# Altium schematic sheet STM32F103RET6.SchDoc
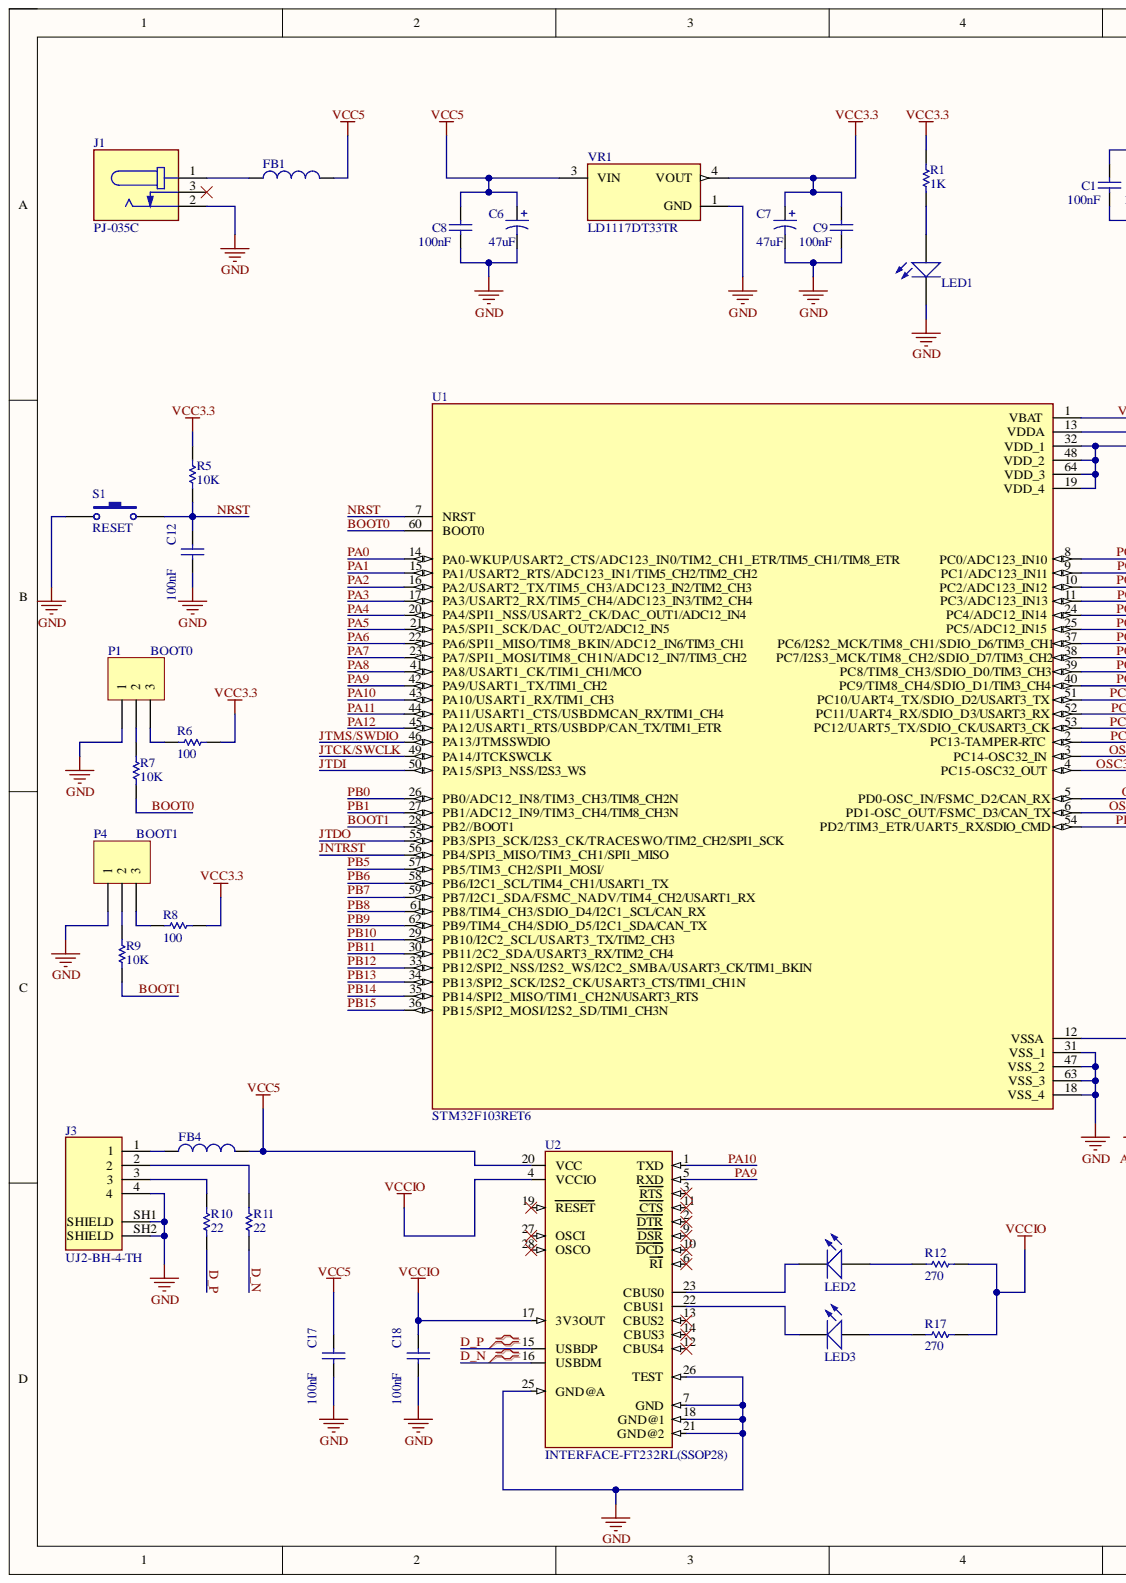
[diagram p0: STM32F103RET6.SchDoc, left]
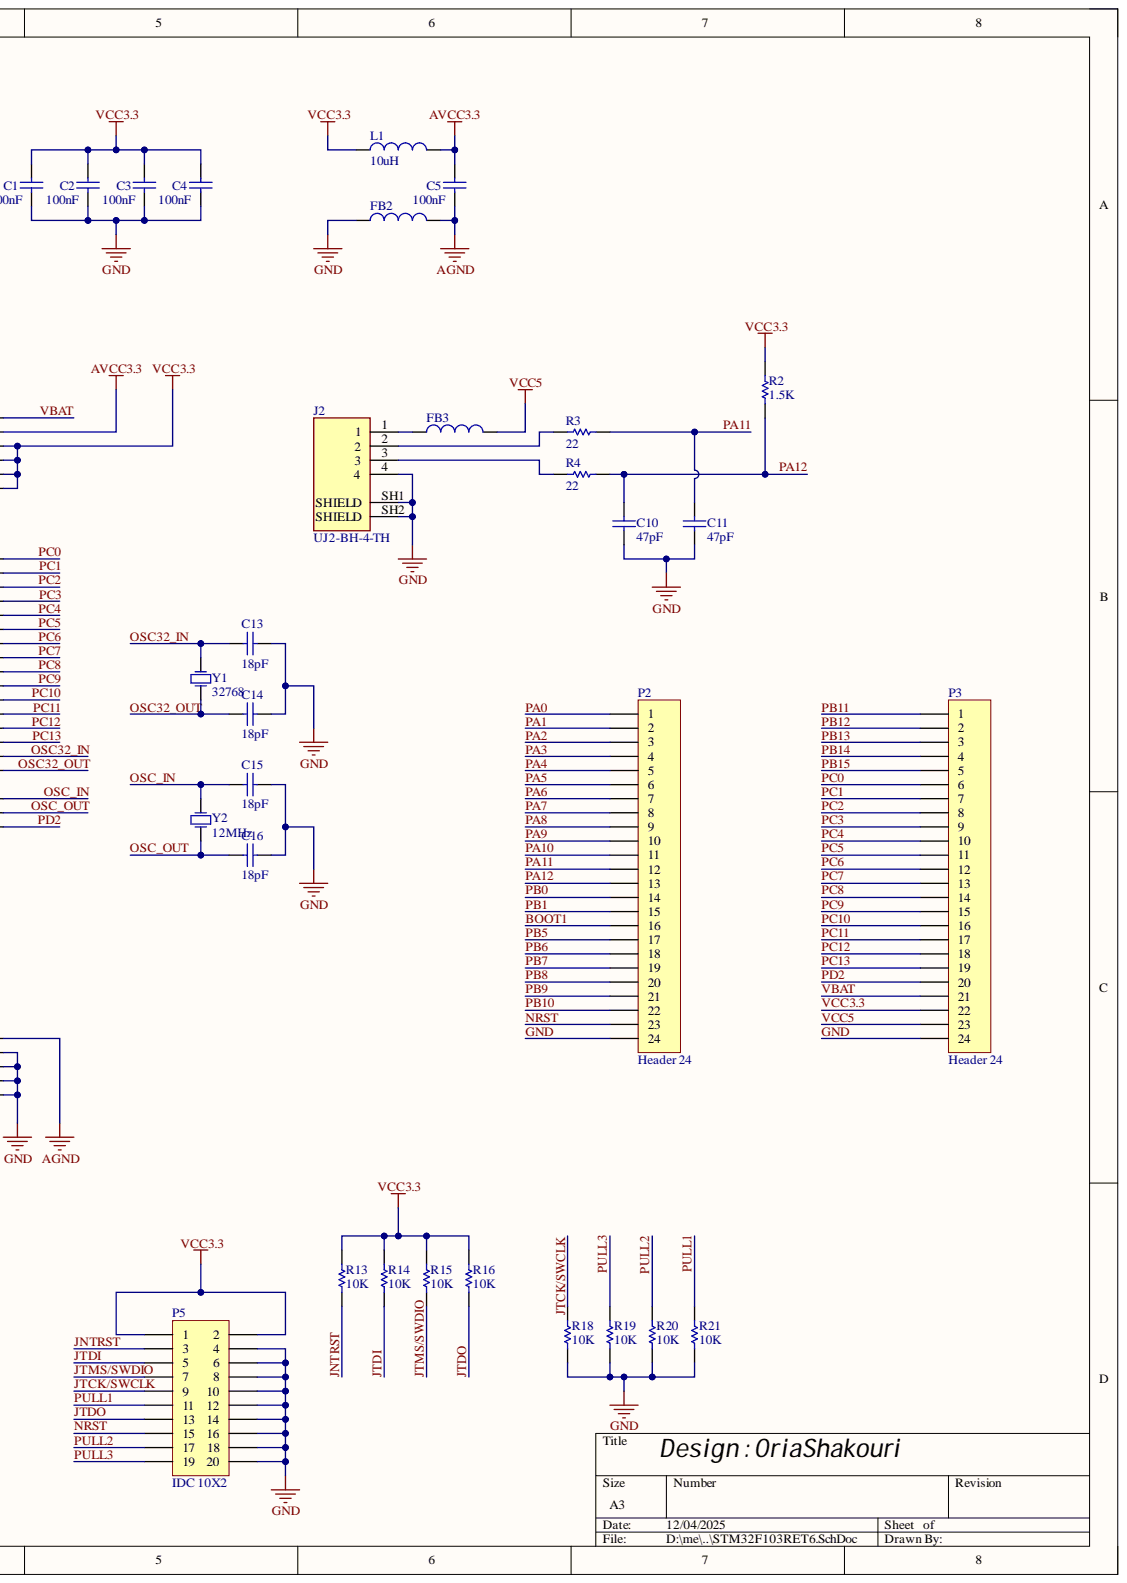
[diagram p1: STM32F103RET6.SchDoc, right]

## Parts
C1  100nF  [CAP0805]
C2  100nF  [CAP0805]
C3  100nF  [CAP0805]
C4  100nF  [CAP0805]
C5  100nF  [CAP0805]
C6  47uF  [CAP_TANTALIUM_SIZEC]
C7  47uF  [CAP_TANTALIUM_SIZEC]
C8  100nF  [CAP0805]
C9  100nF  [CAP0805]
C10  47pF  [CAP0805]
C11  47pF  [CAP0805]
C12  100nF  [CAP0805]
C13  18pF  [CAP0805]
C14  18pF  [CAP0805]
C15  18pF  [CAP0805]
C16  18pF  [CAP0805]
C17  100nF  [CAP0805]
C18  100nF  [CAP0805]
FB1  Ferrite Bead  [ferritebead_0805]  (value not drawn)
FB2  Ferrite Bead  [ferritebead_0805]  (value not drawn)
FB3  Ferrite Bead  [ferritebead_0805]  (value not drawn)
FB4  Ferrite Bead  [ferritebead_0805]  (value not drawn)
J1  PJ-035C  [PJ-035C]
J2  UJ2-BH-4-TH  [USB_TYPE B_2.0]
J3  UJ2-BH-4-TH  [USB_TYPE B_2.0]
L1  10uH  [IND1210]
LED1  LED_SMD_0805  [LED_SMD_0805]  (value not drawn)
LED2  LED_SMD_0805  [LED_SMD_0805]  (value not drawn)
LED3  LED_SMD_0805  [LED_SMD_0805]  (value not drawn)
P1  BOOT0  [Header 3]
P2  Header 24  [HEADER24]
P3  Header 24  [HEADER24]
P4  BOOT1  [Header 3]
P5  IDC 10X2  [IDC 10X2]
R1  1K  [RES0805]
R2  1.5K  [RES0805]
R3  22  [RES0805]
R4  22  [RES0805]
R5  10K  [RES0805]
R6  100  [RES0805]
R7  10K  [RES0805]
R8  100  [RES0805]
R9  10K  [RES0805]
R10  22  [RES0805]
R11  22  [RES0805]
R12  270  [RES0805]
R13  10K  [RES0805]
R14  10K  [RES0805]
R15  10K  [RES0805]
R16  10K  [RES0805]
R17  270  [RES0805]
R18  10K  [RES0805]
R19  10K  [RES0805]
R20  10K  [RES0805]
R21  10K  [RES0805]
S1  RESET  [Push Button Switch SMD]
U1  STM32F103RET6  [QFP50P1200X1200X160-64N]
U2  INTERFACE-FT232RL(SSOP28)  [FT232RL]
VR1  LD1117DT33TR  [LD1117DT33TR]
Y1  32768  [32768_crystal]
Y2  12MHz  [Crystal SMD]

## Nets
AGND: C5.1 FB2.2 U1.12(VSSA)
AVCC3.3: C5.2 L1.2 U1.13(VDDA)
VCCIO: C18.2 R12.1 R17.1 U2.4(VCCIO) U2.17(3V3OUT)
BOOT0: R7.1 U1.60(BOOT0)
BOOT1: P2.16 R9.1 U1.28(PB2//BOOT1)
D_N: R11.2 U2.16(USBDM)
D_P: R10.2 U2.15(USBDP)
GND: C1.1 C2.1 C3.1 C4.1 C6.2 C7.2 C8.1 C9.1 C10.1 C11.1 C12.1 C13.2 C14.2 C15.2 C16.2 C17.1 C18.1 FB2.1 J1.2 J2.4 J2.SH1(SHIELD) J2.SH2(SHIELD) J3.4 J3.SH1(SHIELD) J3.SH2(SHIELD) LED1.1 P1.1 P2.24 P3.24 P4.1 P5.4 P5.6 P5.8 P5.10 P5.12 P5.14 P5.16 P5.18 P5.20 R18.2 R19.2 R20.2 R21.2 S1.1 U1.18(VSS_4) U1.31(VSS_1) U1.47(VSS_2) U1.63(VSS_3) U2.7(GND) U2.18(GND@1) U2.21(GND@2) U2.25(GND@A) U2.26(TEST) VR1.1
JNTRST: P5.3 R13.2 U1.56(PB4/SPI3_MISO/TIM3_CH1/SPI1_MISO)
JTCK/SWCLK: P5.9 R18.1 U1.49(PA14/JTCKSWCLK)
JTDI: P5.5 R14.2 U1.50(PA15/SPI3_NSS/I2S3_WS)
JTDO: P5.13 R16.2 U1.55(PB3/SPI3_SCK/I2S3_CK/TRACESWO/TIM2_CH2/SPI1_SCK)
JTMS/SWDIO: P5.7 R15.2 U1.46(PA13/JTMSSWDIO)
NRST: C12.2 P2.23 P5.15 R5.1 S1.2 U1.7(NRST)
OSC32_IN: C13.1 U1.3(PC14-OSC32_IN) Y1.2
OSC32_OUT: C14.1 U1.4(PC15-OSC32_OUT) Y1.1
OSC_IN: C15.1 U1.5(PD0-OSC_IN/FSMC_D2/CAN_RX) Y2.2
OSC_OUT: C16.1 U1.6(PD1-OSC_OUT/FSMC_D3/CAN_TX) Y2.1
PA0: P2.1 U1.14(PA0-WKUP/USART2_CTS/ADC123_IN0/TIM2_CH1_ETR/TIM5_CH1/TIM8_ETR)
PA1: P2.2 U1.15(PA1/USART2_RTS/ADC123_IN1/TIM5_CH2/TIM2_CH2)
PA2: P2.3 U1.16(PA2/USART2_TX/TIM5_CH3/ADC123_IN2/TIM2_CH3)
PA3: P2.4 U1.17(PA3/USART2_RX/TIM5_CH4/ADC123_IN3/TIM2_CH4)
PA4: P2.5 U1.20(PA4/SPI1_NSS/USART2_CK/DAC_OUT1/ADC12_IN4)
PA5: P2.6 U1.21(PA5/SPI1_SCK/DAC_OUT2/ADC12_IN5)
PA6: P2.7 U1.22(PA6/SPI1_MISO/TIM8_BKIN/ADC12_IN6/TIM3_CH1)
PA7: P2.8 U1.23(PA7/SPI1_MOSI/TIM8_CH1N/ADC12_IN7/TIM3_CH2)
PA8: P2.9 U1.41(PA8/USART1_CK/TIM1_CH1/MCO)
PA9: P2.10 U1.42(PA9/USART1_TX/TIM1_CH2) U2.5(RXD)
PA10: P2.11 U1.43(PA10/USART1_RX/TIM1_CH3) U2.1(TXD)
PA11: C11.2 P2.12 R3.1 U1.44(PA11/USART1_CTS/USBDMCAN_RX/TIM1_CH4)
PA12: C10.2 P2.13 R2.2 R4.2 U1.45(PA12/USART1_RTS/USBDP/CAN_TX/TIM1_ETR)
PB0: P2.14 U1.26(PB0/ADC12_IN8/TIM3_CH3/TIM8_CH2N)
PB1: P2.15 U1.27(PB1/ADC12_IN9/TIM3_CH4/TIM8_CH3N)
PB5: P2.17 U1.57(PB5/TIM3_CH2/SPI1_MOSI/)
PB6: P2.18 U1.58(PB6/I2C1_SCL/TIM4_CH1/USART1_TX)
PB7: P2.19 U1.59(PB7/I2C1_SDA/FSMC_NADV/TIM4_CH2/USART1_RX)
PB8: P2.20 U1.61(PB8/TIM4_CH3/SDIO_D4/I2C1_SCL/CAN_RX)
PB9: P2.21 U1.62(PB9/TIM4_CH4/SDIO_D5/I2C1_SDA/CAN_TX)
PB10: P2.22 U1.29(PB10/I2C2_SCL/USART3_TX/TIM2_CH3)
PB11: P3.1 U1.30(PB11/2C2_SDA/USART3_RX/TIM2_CH4)
PB12: P3.2 U1.33(PB12/SPI2_NSS/I2S2_WS/I2C2_SMBA/USART3_CK/TIM1_BKIN)
PB13: P3.3 U1.34(PB13/SPI2_SCK/I2S2_CK/USART3_CTS/TIM1_CH1N)
PB14: P3.4 U1.35(PB14/SPI2_MISO/TIM1_CH2N/USART3_RTS)
PB15: P3.5 U1.36(PB15/SPI2_MOSI/I2S2_SD/TIM1_CH3N)
PC0: P3.6 U1.8(PC0/ADC123_IN10)
PC1: P3.7 U1.9(PC1/ADC123_IN11)
PC2: P3.8 U1.10(PC2/ADC123_IN12)
PC3: P3.9 U1.11(PC3/ADC123_IN13)
PC4: P3.10 U1.24(PC4/ADC12_IN14)
PC5: P3.11 U1.25(PC5/ADC12_IN15)
PC6: P3.12 U1.37(PC6/I2S2_MCK/TIM8_CH1/SDIO_D6/TIM3_CH1)
PC7: P3.13 U1.38(PC7/I2S3_MCK/TIM8_CH2/SDIO_D7/TIM3_CH2)
PC8: P3.14 U1.39(PC8/TIM8_CH3/SDIO_D0/TIM3_CH3)
PC9: P3.15 U1.40(PC9/TIM8_CH4/SDIO_D1/TIM3_CH4)
PC10: P3.16 U1.51(PC10/UART4_TX/SDIO_D2/USART3_TX)
PC11: P3.17 U1.52(PC11/UART4_RX/SDIO_D3/USART3_RX)
PC12: P3.18 U1.53(PC12/UART5_TX/SDIO_CK/USART3_CK)
PC13: P3.19 U1.2(PC13-TAMPER-RTC)
PD2: P3.20 U1.54(PD2/TIM3_ETR/UART5_RX/SDIO_CMD)
PULL1: P5.11 R21.1
PULL2: P5.17 R20.1
PULL3: P5.19 R19.1
VBAT: P3.21 U1.1(VBAT)
VCC3.3: C1.2 C2.2 C3.2 C4.2 C7.1 C9.2 L1.1 P3.22 P5.1 P5.2 R1.2 R2.1 R5.2 R6.1 R8.1 R13.1 R14.1 R15.1 R16.1 U1.19(VDD_4) U1.32(VDD_1) U1.48(VDD_2) U1.64(VDD_3) VR1.4
VCC5: C6.1 C8.2 C17.2 FB1.2 FB3.2 FB4.2 P3.23 U2.20(VCC) VR1.3
NetFB1_1: FB1.1 J1.1
NetFB3_1: FB3.1 J2.1
NetFB4_1: FB4.1 J3.1
NetJ2_2: J2.2 R3.2
NetJ2_3: J2.3 R4.1
NetJ3_2: J3.2 R11.1
NetJ3_3: J3.3 R10.1
NetLED1_2: LED1.2 R1.1
NetLED2_1: LED2.1 U2.23(CBUS0)
NetLED2_2: LED2.2 R12.2
NetLED3_1: LED3.1 U2.22(CBUS1)
NetLED3_2: LED3.2 R17.2
NetP1_2: P1.2 R7.2
NetP1_3: P1.3 R6.2
NetP4_2: P4.2 R9.2
NetP4_3: P4.3 R8.2

Not connected: J1 3; U2 2(DTR) 3(RTS) 6(RI) 9(DSR) 10(DCD) 11(CTS) 12(CBUS4) 13(CBUS2) 14(CBUS3) 19(RESET) 27(OSCI) 28(OSCO)

## Library data of the parts
C1-C5 C8-C18 = CAP0805: Component Kind: Standard; Description: CAP0805; Library Name: CAP0805.SchLib
C6 C7 = Cap Pol1: Polarized Capacitor (Radial); Component Kind: Standard; LatestRevisionDate: 17-Jul-2002; LatestRevisionNote: Re-released for DXP Platform.; Library Name: Miscellaneous Devices.IntLib; PackageReference: RB7.6-15; Published: 8-Jun-2000; Signal Integrity: Cap Pol; Simulation: CAP; Value: 47uF; Publisher: Altium Limited
FB1-FB4 = Inductor: Code_IEC: 1005; Value: 10mH; Code_JEITA: 0402; Component Kind: Standard; Description: Inductor; LatestRevisionDate: 29-May-2009; LatestRevisionNote: IPC-7351 Footprint Added.; Library Name: Miscellaneous Devices.IntLib; PackageDescription: Chip Inductor; PackageReference: 0402-A; Published: 8-Jun-2000; Signal Integrity: Inductor; Simulation: INDUCTOR; Publisher: Altium Limited
J1 = PJ-035C: MF: {'manufacturer': u'CUI Devices'}; Availability: Unavailable; Component Kind: Standard; Library Name: PJ-035C.IntLib; MP: PJ-035C; Package: None; Price: None
J2 J3 = UJ2-BH-4-TH: Type B, USB 2.0, 1 A, Right Angle, Through Hole, USB Receptacle; MF: CUI Devices; Availability: In Stock; Check_prices: https://www.snapeda.com/parts/UJ2-BH-4-TH/CUI+Devices/view-part/?ref=eda; Component Kind: Standard; CUI_purchase_URL: https://www.cuidevices.com/product/interconnect/connectors/usb-connectors/uj2-bh-th-series?utm_source=snapeda.com&utm_medium=referral&utm_campaign=snapedaBOM; Library Name: UJ2-BH-4-TH.IntLib; MP: UJ2-BH-4-TH; Package: None; Price: None; Purchase-URL: https://pricing.snapeda.com/search/part/UJ2-BH-4-TH/?ref=eda; SnapEDA_Link: https://www.snapeda.com/parts/UJ2-BH-4-TH/CUI+Devices/view-part/?ref=snap
L1 = Inductor: Code_IEC: 1005; Code_JEITA: 0402; Component Kind: Standard; Description: Inductor; LatestRevisionDate: 29-May-2009; LatestRevisionNote: IPC-7351 Footprint Added.; Library Name: Miscellaneous Devices.IntLib; PackageDescription: Chip Inductor; PackageReference: 0402-A; Published: 8-Jun-2000; Signal Integrity: Inductor; Simulation: INDUCTOR; Value: 10uH; Publisher: Altium Limited
P1 P4 = Header 3: Component Kind: Standard; Library Name: Header 3.SchLib
P2 P3 = Header 24: Header, 24-Pin; Component Kind: Standard; LatestRevisionDate: 17-Jul-2002; LatestRevisionNote: Re-released for DXP Platform.; Library Name: Miscellaneous Connectors.IntLib; Signal Integrity: Connector; Publisher: Altium Limited
R1-R21 = RES0805: Component Kind: Standard; Description: RES0805; Library Name: RES0805.SchLib
S1 = SW-PB: Switch; Component Kind: Standard; LatestRevisionDate: 17-Jul-2002; LatestRevisionNote: Re-released for DXP Platform.; Library Name: Miscellaneous Devices.IntLib; PackageReference: SPST-2; Published: 24-Mar-1999; Publisher: Altium Limited
U1 = STM32F103RET6: ARMÂ® CortexÂ®-M3 STM32F1 Microcontroller IC 32-Bit 72MHz 512KB (512K x 8) FLASH 64-LQFP (10x10); MF: STMicroelectronics; Check_prices: https://www.snapeda.com/parts/STM32F103RET6/STMicroelectronics/view-part/?ref=eda; Component Kind: Standard; Library Name: STM32F103RET6.IntLib; MP: STM32F103RET6; Package: LQFP-64 STMicroelectronics; SnapEDA_Link: https://www.snapeda.com/parts/STM32F103RET6/STMicroelectronics/view-part/?ref=snap
U2 = INTERFACE-FT232RL(SSOP28): USB-Ð¼Ð¾ÑÑ‚, Ð¸Ð½Ñ‚ÐµÑ€Ñ„ÐµÐ¹Ñ USB Ðº UART USB 2.0 UART 28-SSOP; MF: FTDI, Future; Availability: Not in stock; Check_prices: https://www.snapeda.com/parts/FT232RL/FTDI%252C+Future+Technology+Devices+International+Ltd/view-part/?ref=eda; Component Kind: Standard; Library Name: INTERFACE-FT232RL_SSOP28_.IntLib; MP: FT232RL; Package: SSOP-28 FTDI; Price: None; SnapEDA_Link: https://www.snapeda.com/parts/FT232RL/FTDI%252C+Future+Technology+Devices+International+Ltd/view-part/?ref=snap
VR1 = LD1117DT33TR: Linear Voltage Regulator IC 1 Output 800mA DPAK; MF: STMicroelectronics; Availability: Unavailable; Component Kind: Standard; Library Name: LD1117DT33TR.IntLib; MP: LD1117DT33TR; Package: TO-252 STMicroelectronics; Price: None; SnapEDA_Link: https://www.snapeda.com/parts/LD1117DT33TR/STMicroelectronics/view-part/8105/?ref=snap
Y1 Y2 = Crystal SMD: Component Kind: Standard; Description: Crystal SMD; Library Name: Crystal SMD.SchLib
LED1-LED3 = LED_SMD_0805: Component Kind: Standard; Library Name: LED_SMD_0805.SchLib
P5 = IDC 10X2: Component Kind: Standard; Library Name: IDC 10X2.SchLib
